# Altium schematic sheet base-board.SchDoc(base-board)
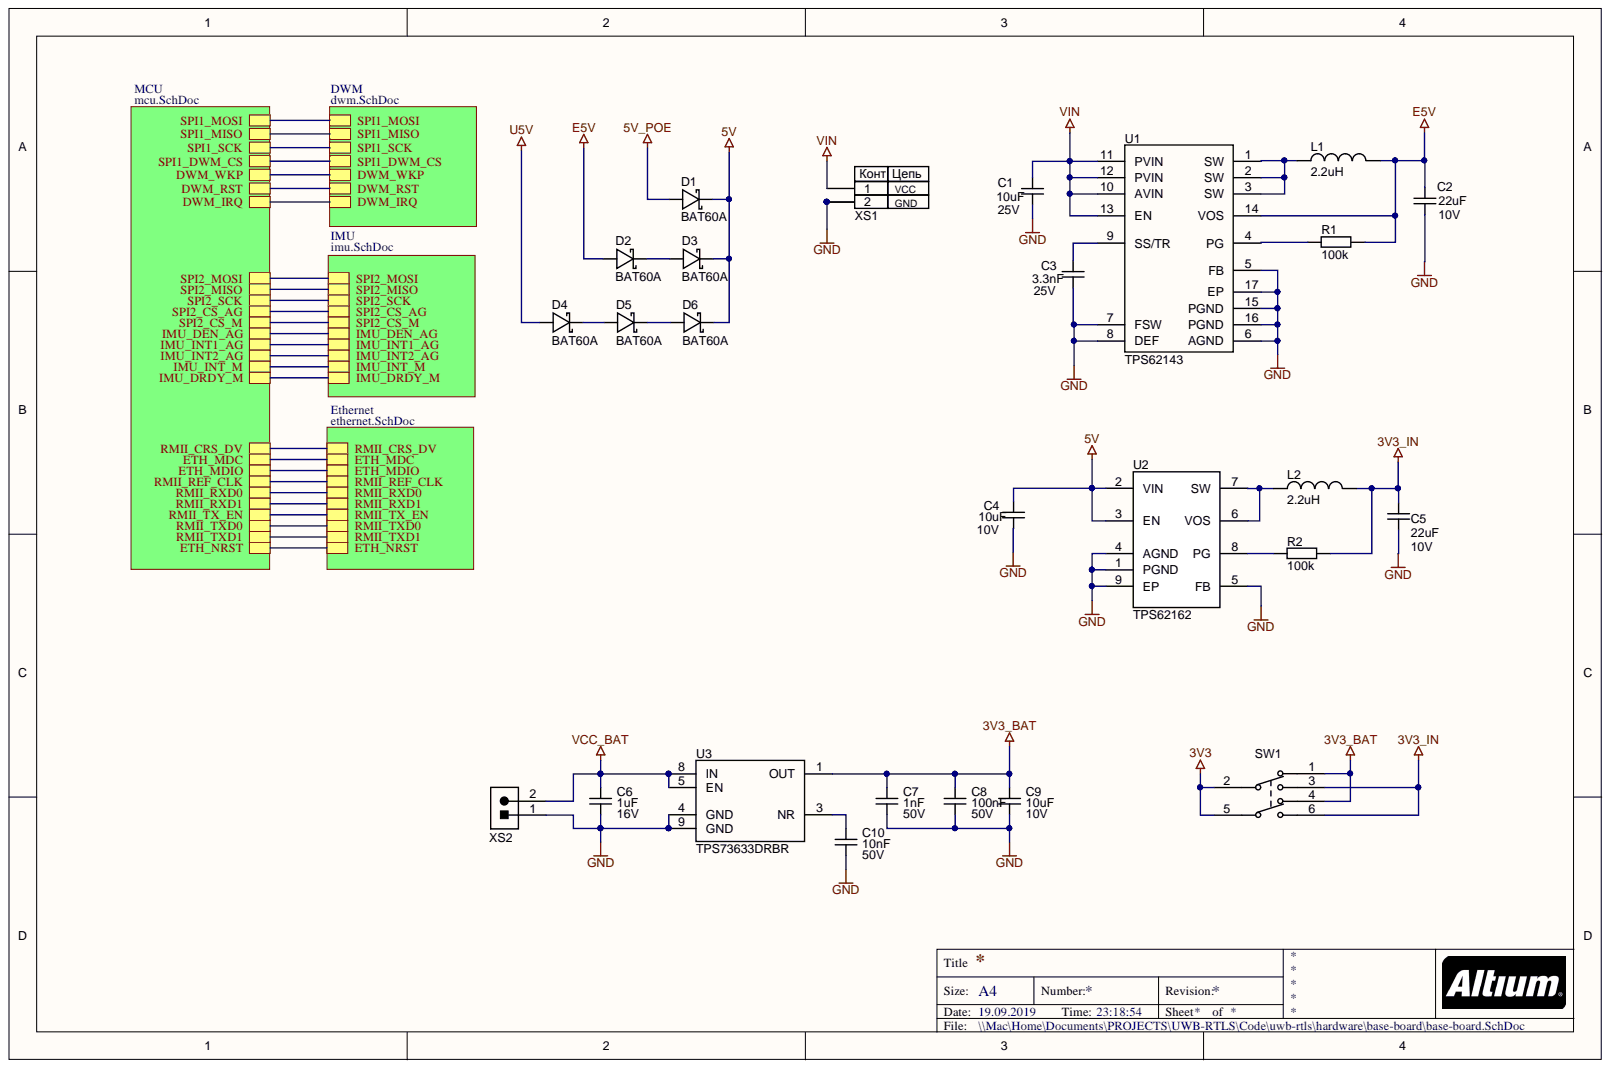
[diagram p0: base-board.SchDoc]

## Parts
C1  value 10uF  Voltage: 25V  [C1210]  (hidden comment: C1210)
C2  value 22uF  Voltage: 10V  [C1210]  (hidden comment: C1210)
C3  value 3.3nF  Voltage: 25V  [C0603]  (hidden comment: C0603)
C4  value 10uF  Voltage: 10V  [C0805]  (hidden comment: C0805)
C5  value 22uF  Voltage: 10V  [C0805]  (hidden comment: C0805)
C6  value 1uF  Voltage: 16V  [C0805]  (hidden comment: C0805)
C7  value 1nF  Voltage: 50V  [C0805]  (hidden comment: C0805)
C8  value 100nF  Voltage: 50V  [C0603]  (hidden comment: C0603)
C9  value 10uF  Voltage: 10V  [C0603]  (hidden comment: C0603)
C10  value 10nF  Voltage: 50V  [C0603]  (hidden comment: C0603)
D1  BAT60A  [SOD-323-2]
D2  BAT60A  [SOD-323-2]
D3  BAT60A  [SOD-323-2]
D4  BAT60A  [SOD-323-2]
D5  BAT60A  [SOD-323-2]
D6  BAT60A  [SOD-323-2]
L1  value 2.2uH  [IND2220]  (hidden comment: LQH55PN2R2NR0)
L2  value 2.2uH  [IND2220]  (hidden comment: LQH55PN2R2NR0)
R1  value 100k  [SMD0603]  (hidden comment: R0603)
R2  value 100k  [SMD0603]  (hidden comment: R0603)
SW1  JS202011SCQN  [JS202011SCQN]  (value not drawn)
U1  TPS62143  [QFN-16]
U2  TPS62162  [SON-8]
U3  TPS73633DRBR  [VSON-8]
XS1  PowerJack  [PowerJack]  (value not drawn)
XS2  S2B-PH-K-S  [S2B-PH-K-S]  (value not drawn)

## Nets
3V3: SW1.2 SW1.5
3V3_BAT: C7.2 C8.2 C9.2 SW1.1 SW1.4 U3.1(OUT)
3V3_IN: C5.2 L2.2 R2.2 SW1.3 SW1.6
5V: C4.2 D1.2 D3.2 D6.2 U2.2(VIN) U2.3(EN)
5V_POE: D1.1
E5V: C2.2 D2.1 L1.2 R1.2 U1.14(VOS)
GND: C1.1 C2.1 C3.1 C4.1 C5.1 C6.1 C7.1 C8.1 C9.1 C10.1 U1.5(FB) U1.6(AGND) U1.7(FSW) U1.8(DEF) U1.15(PGND) U1.16(PGND) U1.17(EP) U2.1(PGND) U2.4(AGND) U2.5(FB) U2.9(EP) U3.4(GND) U3.9(GND) XS1.2 XS1.3 XS2.1
U5V: D4.1
VCC_BAT: C6.2 U3.5(EN) U3.8(IN) XS2.2
VIN: C1.2 U1.10(AVIN) U1.11(PVIN) U1.12(PVIN) U1.13(EN) XS1.1
NetC3_2: C3.2 U1.9(SS/TR)
NetC10_2: C10.2 U3.3(NR)
NetD2_2: D2.2 D3.1
NetD4_2: D4.2 D5.1
NetD5_2: D5.2 D6.1
NetL1_1: L1.1 U1.1(SW) U1.2(SW) U1.3(SW)
NetL2_1: L2.1 U2.6(VOS) U2.7(SW)
NetR1_1: R1.1 U1.4(PG)
NetR2_1: R2.1 U2.8(PG)
DWM_IRQ:
DWM_RST:
DWM_WKP:
ETH_MDC:
ETH_MDIO:
ETH_NRST:
IMU_DEN_AG:
IMU_DRDY_M:
IMU_INT1_AG:
IMU_INT2_AG:
IMU_INT_M:
RMII_CRS_DV:
RMII_REF_CLK:
RMII_RXD0:
RMII_RXD1:
RMII_TX_EN:
RMII_TXD0:
RMII_TXD1:
SPI1_DWM_CS:
SPI1_MISO:
SPI1_MOSI:
SPI1_SCK:
SPI2_CS_AG:
SPI2_CS_M:
SPI2_MISO:
SPI2_MOSI:
SPI2_SCK:

## Library data of the parts
D1-D6 = BAT60J: Shottky Diode 10V 3A; Library Name: DZ_LIB.SchLib; Component Kind: Standard
L1 L2 = LQH55PN2R2NR0: FIXED IND 2.2UH 2.5A 31 MOHM SMD; Model: LQH55PN2R2NR0; Library Name: DZ_LIB.SchLib; Component Kind: Standard
SW1 = JS202011SCQN: Switch Slide DPDT, 0.3 A, 6 V, -40 to 85 degC, 6-Pin SMD, RoHS, Tape and Reel; Manufacturer: C&K Components; Minimum Insulation Resistance: 100MOhm; Maximum DC Voltage Rating: 6V; Maximum Contact Resistance: 70mOhm; Electrical Life: 15000Cycles; Dielectric Strength: 500VAC; COO: US; Contact Rating @ Voltage: 0.3@6VDCA; Body Orientation: Straight; Actuator Style: TopSlide; Actuator Material: Nylon4/6; Actuator Color: Black; Type: Sub-Miniature; Switch Configuration: N/A; Supplier Standard Pack: 5,000; Supplier: C&KComponents; Seal Location: N/A; Seal Description: N/A; RoHS: Yes; Product Weight: N/A; Pole Throw Configuration: DPDT; Part No: JS202011SCQN; Packaging: TapeandReel; Operating Temperature: -40to85C; Mounting: SurfaceMount,GullWing; Mechanical Life: N/A; Maximum AC Voltage Rating: N/A; Manufacturer URL: http://www.ckswitches.com; Library Name: DZ_LIB.SchLib; HTS: 8536509050; Housing Material: Nylon4/6; Family: JS; ECCN: EAR99; Dimension: 9x7.5x5.5mm; Datasheet Version: Sep-15; Datasheet URL: http://datasheet.octopart.com/JS202011SCQN-C%26K-Components-datasheet-62100994.pdf; Contact Plating: Silver; Contact Material: CopperAlloy; Component Kind: Standard; Base Material: N/A
U1 = TPS62143RGT16: Buck 2A TI Fix 5V; Library Name: DZ_LIB.SchLib; Component Kind: Standard
U2 = TPS62162DSG8: Buck 1A TI Fix 3.3V; Library Name: DZ_LIB.SchLib; Component Kind: Standard
U3 = TPS73633DRBR: IC REG LINEAR 3.3V 400MA 8SON; Library Name: DZ_LIB.SchLib; Component Kind: Standard
XS2 = S2B-PH-K-S: Male Header, Pitch 2 mm, 1 x 2 Position, Height 4.8 mm, Tail Length 3.4 mm, -25 to 85 degC, RoHS, Bulk; Voltage Rating (DC): 50 V; Tolerance: 20%; Case\Package: Radial; Capacitance: 10 uF; Voltage Rating: 50 V; RoHSCompliant: true; REACH SVHC: No SVHC; Radiation Hardening: No; Pins: 2; Packaging: Bulk; PackageReference: S2B-PH-K-S; Package Quantity: 200; PackageDescription: Male Header, Pitch 2 mm, 1 x 2 Position, Height 4.8 mm, Tail Length 3.4 mm; Mount: Through Hole; Min Operating Temperature: -40 degC; Max Operating Temperature: 85 degC; Library Name: DZ_LIB.SchLib; Length: 11 mm; Lead Pitch: 2 mm; Height: 11 mm; Diameter: 5 mm; DatasheetVersion: Dec-12; ComponentLink1URL: http://www.jst-mfg.com/product/pdf/eng/ePH.pdf; ComponentLink1Description: Datasheet; Component Kind: Standard
C1 C2 = C1210: Library Name: DZ_LIB.SchLib; Component Kind: Standard
C3 C8-C10 = C0603: Library Name: DZ_LIB.SchLib; Component Kind: Standard
C4-C7 = C0805: Library Name: DZ_LIB.SchLib; Component Kind: Standard
R1 R2 = R0603: Library Name: DZ_LIB.SchLib; Component Kind: Standard
XS1 = PowerJack: Library Name: DZ_LIB.SchLib; Component Kind: Standard
